# Altium schematic sheet MCU.SchDoc(MCU)
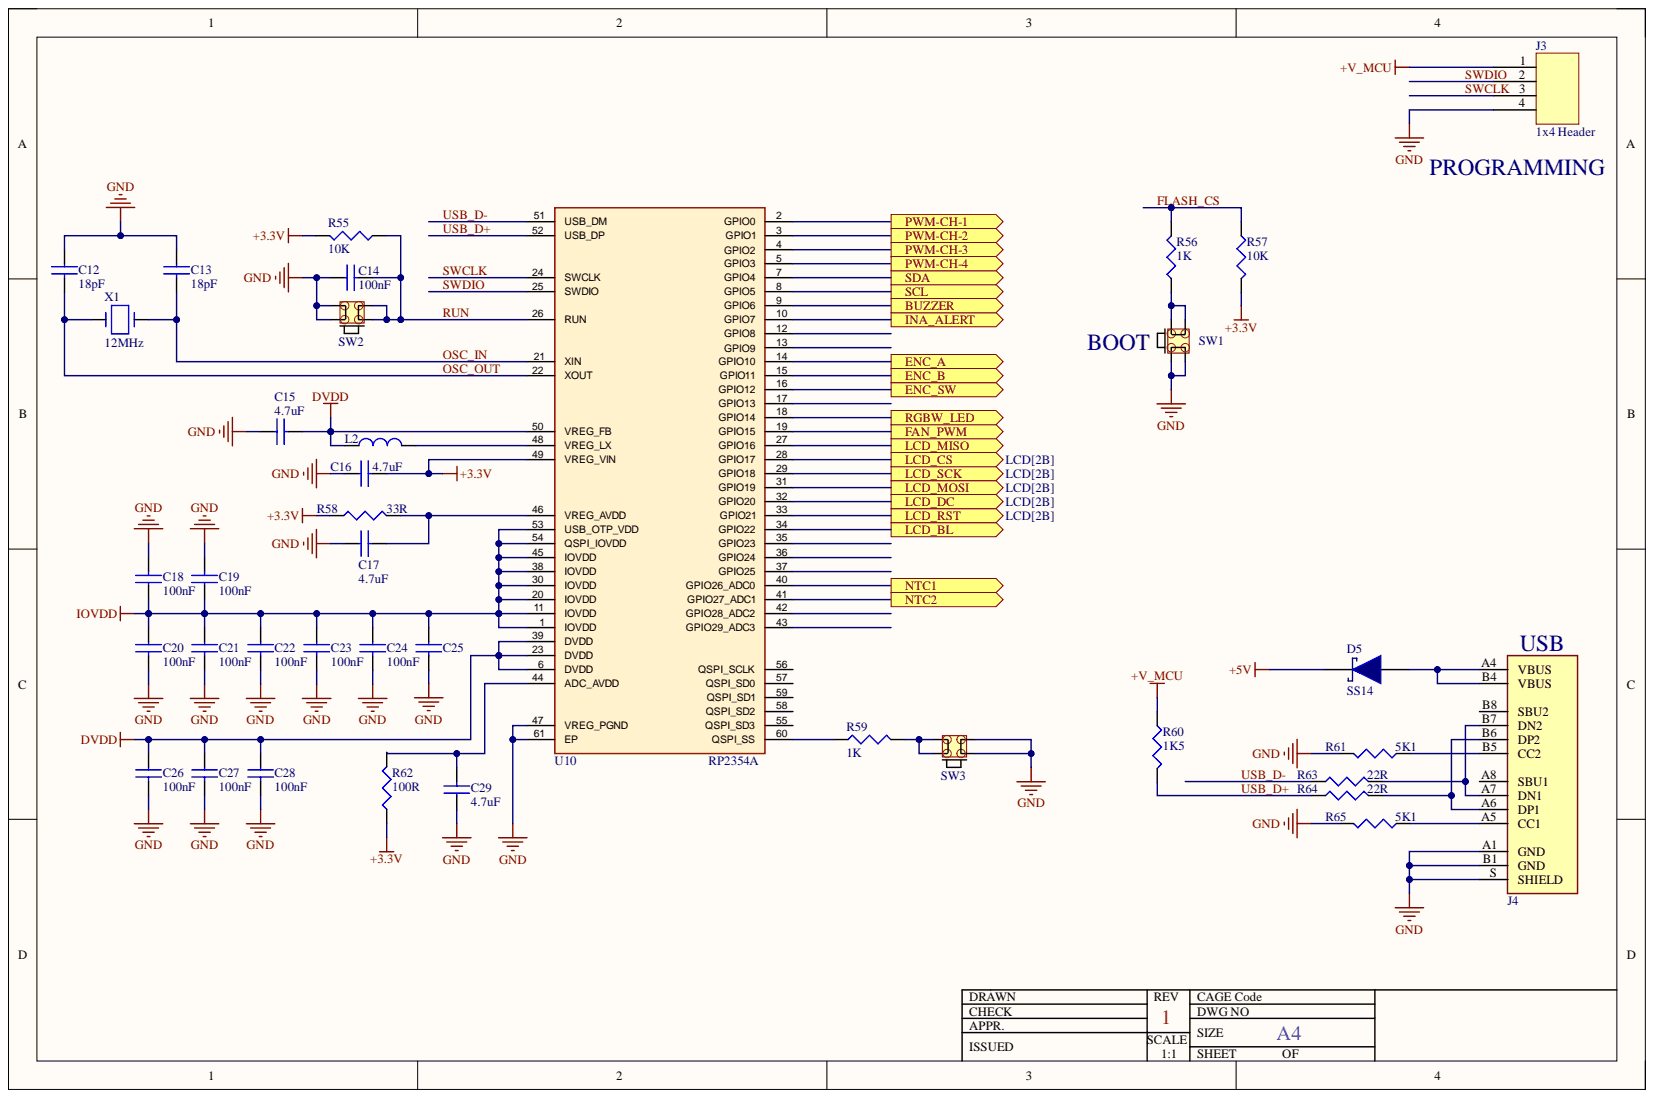
[diagram p0: MCU.SchDoc]

## Parts
C12  value 18pF  [0805 SMD Ceramic Capacitor]  (hidden comment: C0805C180)
C13  value 18pF  [0805 SMD Ceramic Capacitor]  (hidden comment: C0805C180)
C14  value 100nF  [0805 SMD Ceramic Capacitor]  (hidden comment: C0805C104)
C15  value 4.7uF  [1206 SMD Ceramic Capacitor]  (hidden comment: C1206C475)
C16  value 4.7uF  [1206 SMD Ceramic Capacitor]  (hidden comment: C1206C475)
C17  value 4.7uF  [1206 SMD Ceramic Capacitor]  (hidden comment: C1206C475)
C18  value 100nF  [0805 SMD Ceramic Capacitor]  (hidden comment: C0805C104)
C19  value 100nF  [0805 SMD Ceramic Capacitor]  (hidden comment: C0805C104)
C20  value 100nF  [0805 SMD Ceramic Capacitor]  (hidden comment: C0805C104)
C21  value 100nF  [0805 SMD Ceramic Capacitor]  (hidden comment: C0805C104)
C22  value 100nF  [0805 SMD Ceramic Capacitor]  (hidden comment: C0805C104)
C23  value 100nF  [0805 SMD Ceramic Capacitor]  (hidden comment: C0805C104)
C24  value 100nF  [0805 SMD Ceramic Capacitor]  (hidden comment: C0805C104)
C25  C0805C104  [0805 SMD Ceramic Capacitor]  (value not drawn)
C26  value 100nF  [0805 SMD Ceramic Capacitor]  (hidden comment: C0805C104)
C27  value 100nF  [0805 SMD Ceramic Capacitor]  (hidden comment: C0805C104)
C28  value 100nF  [0805 SMD Ceramic Capacitor]  (hidden comment: C0805C104)
C29  value 4.7uF  [1206 SMD Ceramic Capacitor]  (hidden comment: C1206C475)
D5  SS14  [SMA]
J3  value 1x4 Header  [1x4 4 Pin Header]  (hidden comment: 1x4 4 Pin Header)
J4  TYPE-C-31-M-12  [HRO_TYPE-C-31-M-12]  (value not drawn)
L2  0805Ferrite  [0805 Inductor]  (value not drawn)
R55  value 10K  [0805 Resistor]  (hidden comment: 0805_10K)
R56  value 1K  [0805 Resistor]  (hidden comment: 0805_1K)
R57  value 10K  [0805 Resistor]  (hidden comment: 0805_10K)
R58  value 33R  [0805 Resistor]  (hidden comment: 0805_33R)
R59  value 1K  [0805 Resistor]  (hidden comment: 0805_1K)
R60  value 1K5  [0805 Resistor]  (hidden comment: 0805_1K5)
R61  value 5K1  [0805 Resistor]  (hidden comment: 0805_5K1%1)
R62  value 100R  [0805 Resistor]  (hidden comment: 0805_100R%1)
R63  value 22R  [0805 Resistor]  (hidden comment: 0805_22R%1)
R64  value 22R  [0805 Resistor]  (hidden comment: 0805_22R%1)
R65  value 5K1  [0805 Resistor]  (hidden comment: 0805_5K1%1)
SW1  DS1042-03-1-1-KKR-250-10  [DS1042-03-1-1-KKR-250-10]  (value not drawn)
SW2  DS1042-03-1-1-KKR-250-10  [DS1042-03-1-1-KKR-250-10]  (value not drawn)
SW3  DS1042-03-1-1-KKR-250-10  [DS1042-03-1-1-KKR-250-10]  (value not drawn)
U10  RP2354A  [RP2354A]
X1  value 12MHz  Load Capacitance: 18pF  [SMD5032-2P]  (hidden comment: SMD XTAL 12MHz - 3)

## Ports
BUZZER, ENC_A, ENC_B, ENC_SW, FAN_PWM, INA_ALERT, LCD_BL, LCD_CS, LCD_DC, LCD_MISO, LCD_MOSI, LCD_RST, LCD_SCK, NTC1, NTC2, PWM-CH-1, PWM-CH-2, PWM-CH-3, PWM-CH-4, RGBW_LED, SCL, SDA

## Nets
+3.3V: C16.2 R55.1 R57.2 R58.1 R62.1 U10.49(VREG_VIN)
+5V: D5.2
+V_MCU: J3.1 R60.2
DVDD: C15.2 C26.1 C27.1 C28.1 L2.1 U10.6(DVDD) U10.23(DVDD) U10.39(DVDD) U10.50(VREG_FB)
GND: C12.1 C13.1 C14.1 C15.1 C16.1 C17.1 C18.2 C19.2 C20.2 C21.2 C22.2 C23.2 C24.2 C25.2 C26.2 C27.2 C28.2 C29.1 J3.4 J4.A1(GND) J4.B1(GND) J4.S(SHIELD) R61.1 R65.1 SW1.1 SW1.3 SW2.2 SW2.4 SW3.1 SW3.3 U10.47(VREG_PGND) U10.61(EP)
IOVDD: C18.1 C19.1 C20.1 C21.1 C22.1 C23.1 C24.1 C25.1 U10.1(IOVDD) U10.11(IOVDD) U10.20(IOVDD) U10.30(IOVDD) U10.38(IOVDD) U10.45(IOVDD) U10.53(USB_OTP_VDD) U10.54(QSPI_IOVDD)
BUZZER: U10.9(GPIO6) ; port BUZZER
ENC_A: U10.14(GPIO10) ; port ENC_A
ENC_B: U10.15(GPIO11) ; port ENC_B
ENC_SW: U10.16(GPIO12) ; port ENC_SW
FAN_PWM: U10.19(GPIO15) ; port FAN_PWM
FLASH_CS: R56.2 R57.1
INA_ALERT: U10.10(GPIO7) ; port INA_ALERT
LCD_BL: U10.34(GPIO22) ; port LCD_BL
LCD_CS: U10.28(GPIO17) ; port LCD_CS
LCD_DC: U10.32(GPIO20) ; port LCD_DC
LCD_MISO: U10.27(GPIO16) ; port LCD_MISO
LCD_MOSI: U10.31(GPIO19) ; port LCD_MOSI
LCD_RST: U10.33(GPIO21) ; port LCD_RST
LCD_SCK: U10.29(GPIO18) ; port LCD_SCK
NTC1: U10.40(GPIO26_ADC0) ; port NTC1
NTC2: U10.41(GPIO27_ADC1) ; port NTC2
OSC_IN: C13.2 U10.21(XIN) X1.1
OSC_OUT: C12.2 U10.22(XOUT) X1.2
PWM-CH-1: U10.2(GPIO0) ; port PWM-CH-1
PWM-CH-2: U10.3(GPIO1) ; port PWM-CH-2
PWM-CH-3: U10.4(GPIO2) ; port PWM-CH-3
PWM-CH-4: U10.5(GPIO3) ; port PWM-CH-4
RGBW_LED: U10.18(GPIO14) ; port RGBW_LED
RUN: C14.2 R55.2 SW2.1 SW2.3 U10.26(RUN)
SCL: U10.8(GPIO5) ; port SCL
SDA: U10.7(GPIO4) ; port SDA
SWCLK: J3.3 U10.24(SWCLK)
SWDIO: J3.2 U10.25(SWDIO)
USB_D+: R60.1 R64.2 U10.52(USB_DP)
USB_D-: R63.2 U10.51(USB_DM)
NetC17_2: C17.2 R58.2 U10.46(VREG_AVDD)
NetC29_2: C29.2 R62.2 U10.44(ADC_AVDD)
NetD5_1: D5.1 J4.A4(VBUS) J4.B4(VBUS)
NetJ4_A5: J4.A5(CC1) R65.2
NetJ4_A6: J4.A6(DP1) J4.B6(DP2) R64.1
NetJ4_A7: J4.A7(DN1) J4.B7(DN2) R63.1
NetJ4_B5: J4.B5(CC2) R61.2
NetL2_2: L2.2 U10.48(VREG_LX)
NetR56_1: R56.1 SW1.2 SW1.4
NetR59_1: R59.1 U10.60(QSPI_SS)
NetR59_2: R59.2 SW3.2 SW3.4

Not connected: J4 A8(SBU1) B8(SBU2); U10 12(GPIO8) 13(GPIO9) 17(GPIO13) 35(GPIO23) 36(GPIO24) 37(GPIO25) 42(GPIO28_ADC2) 43(GPIO29_ADC3) 55(QSPI_SD3) 56(QSPI_SCLK) 57(QSPI_SD0) 58(QSPI_SD2) 59(QSPI_SD1)

## Library data of the parts
C12 C13 = C0805C180: Manufacturer: KEMET; Manufacturer Code: C0805C180J5GACTU; Voltage: 50V; Component Kind: Standard; Farnell Code: 1414673; Library Name: Capacitors.SchLib; Package: 0805; Unit Price: 0,038 €
C14 C18-C28 = C0805C104: Manufacturer: KEMET; Manufacturer Code: C0805C104J5RACTU; Voltage: 50V; Component Kind: Standard; Farnell Code: 1414663; Library Name: Capacitors.SchLib; Package: 0805; Unit Price: 0,06 €
C15-C17 C29 = C1206C475: CAP CER 4.7UF 16V X7R 1206; Manufacturer: Samsung Electro-Mechanics; Manufacturer Code: CL31B475KOHNFNE; Capacitance Tolerance: ±10%; Component Kind: Standard; Digikey Code: 1276-3181-1-ND; Library Name: Capacitors.SchLib; MOQ: 1; Unit Price: 0.18 $; Voltage: 16V
D5 = SS14: Manufacturer: onsemi; Forward Current: 1A; Voltage: 40V; Component Kind: Standard; Digikey Code: SS14TR-ND; Library Name: SS14.SchLib; Manufacturer Code: SS14; Unit Price: 0.29 $; Value: SS14
J4 = TYPE-C-31-M-12: USB Type C Connector; Manufacturer: HRO Electronics Co., Ltd.; Component Kind: Standard; Library Name: TYPE-C-31-M-12.SchLib; Manufacturer Code: TYPE-C-31-M-12; Unit Price: 0.0438
L2 = 0805Ferrite: Manufacturer: TDK; Manufacturer Code: MMZ2012R102A; Current: 500mA; Value: 1K; Component Kind: Standard; Farnell Code: 1669719; Library Name: FerriteBead.SchLib; Unit Price: 0,059 €
R55 R57 = 0805_10K: Manufacturer: MULTICOMP; Manufacturer Code: MC 0.1W 0805 1% 10K; Tolerance: ±1%; Component Kind: Standard; Farnell Code: 9332391; Library Name: Resistors.SchLib; Unit Price: 0,03 €
R56 R59 = 0805_1K: Manufacturer: MULTICOMP; Manufacturer Code: MC01W080511K; Tolerance: ±1%; Component Kind: Standard; Farnell Code: 9332383; Library Name: Resistors.SchLib; Unit Price: 0,03 €
R58 = 0805_33R: Manufacturer: MULTICOMP; Manufacturer Code: MC 0.1W 0805 1% 33R; Tolerance: ±1%; Component Kind: Standard; Farnell Code: 9333088; Library Name: Resistors.SchLib; Unit Price: 0,03 €
R60 = 0805_1K5: Manufacturer: MULTICOMP; Manufacturer Code: MCPWR05FTEW1501; Tolerance: ±1%; Component Kind: Standard; Farnell Code: 1887285; Library Name: Resistors.SchLib; Unit Price: 0,047 €
R61 R65 = 0805_5K1%1: 5.1 kOhms ±5% 0.125W, 1/8W Chip Resistor 0805 (2012 Metric) Moisture Resistant Thick Film; Manufacturer: YAGEO; Manufacturer Code: RC0805JR-075K1L; Component Kind: Standard; Digikey Code: 1469942; Library Name: Resistors.SchLib; Tolerance: ±5%; Unit Price: 0,01 $
R62 = 0805_100R%1: Manufacturer: MULTICOMP; Manufacturer Code: MC 0.1W 0805 1% 100R; Tolerance: ±1%; Component Kind: Standard; Farnell Code: 9332375; Library Name: Resistors.SchLib; Unit Price: 0,03 €
R63 R64 = 0805_22R%1: Manufacturer: MULTICOMP; Manufacturer Code: MC01W0805122R; Tolerance: ±1%; Component Kind: Standard; Farnell Code: 9332847; Library Name: Resistors.SchLib; MOQ: 10; Unit Price: 0,02 €
SW1-SW3 = DS1042-03-1-1-KKR-250-10: SWITCH TACT 6.00x6.00 4.30mm 250gF SMT V/T; Manufacturer: CONNFLY; Component Kind: Standard; Digikey Code: None; Library Name: ButtonSwitch.SchLib; Manufacturer Code: DS1042-03-1-1-KKR-250-10; Package: None; Price Unit: $; Unit Price: 0,0488; Value: DS1042-03-1-1-KKR-250-10
U10 = RP2354A: Raspberry Pi RP2354A MCU QFN60; Component Kind: Standard; Digikey Code: None; Library Name: RP2354A.SchLib; Manufacturer: Raspberry Pi; Manufacturer Code: RP2354A; Package: QFN60; Unit Price: 1.3177; Value: RP2354A
X1 = SMD XTAL 12MHz - 3: Manufacturer: ABRACON; Manufacturer Code: ABM7-12.000MHZ-D2Y-T; Frequency Stability: ±30ppm; Frequency Tolerance: ±20ppm; Component Kind: Standard; Farnell Code: 2101331; Library Name: Crystal.SchLib; MOQ: 1; Unit Price: 0,861 €
J3 = 1x4 4 Pin Header: Component Kind: Standard; Library Name: Header.SchLib
